# Altium schematic sheet Power_v2.SchDoc(Power_v2)
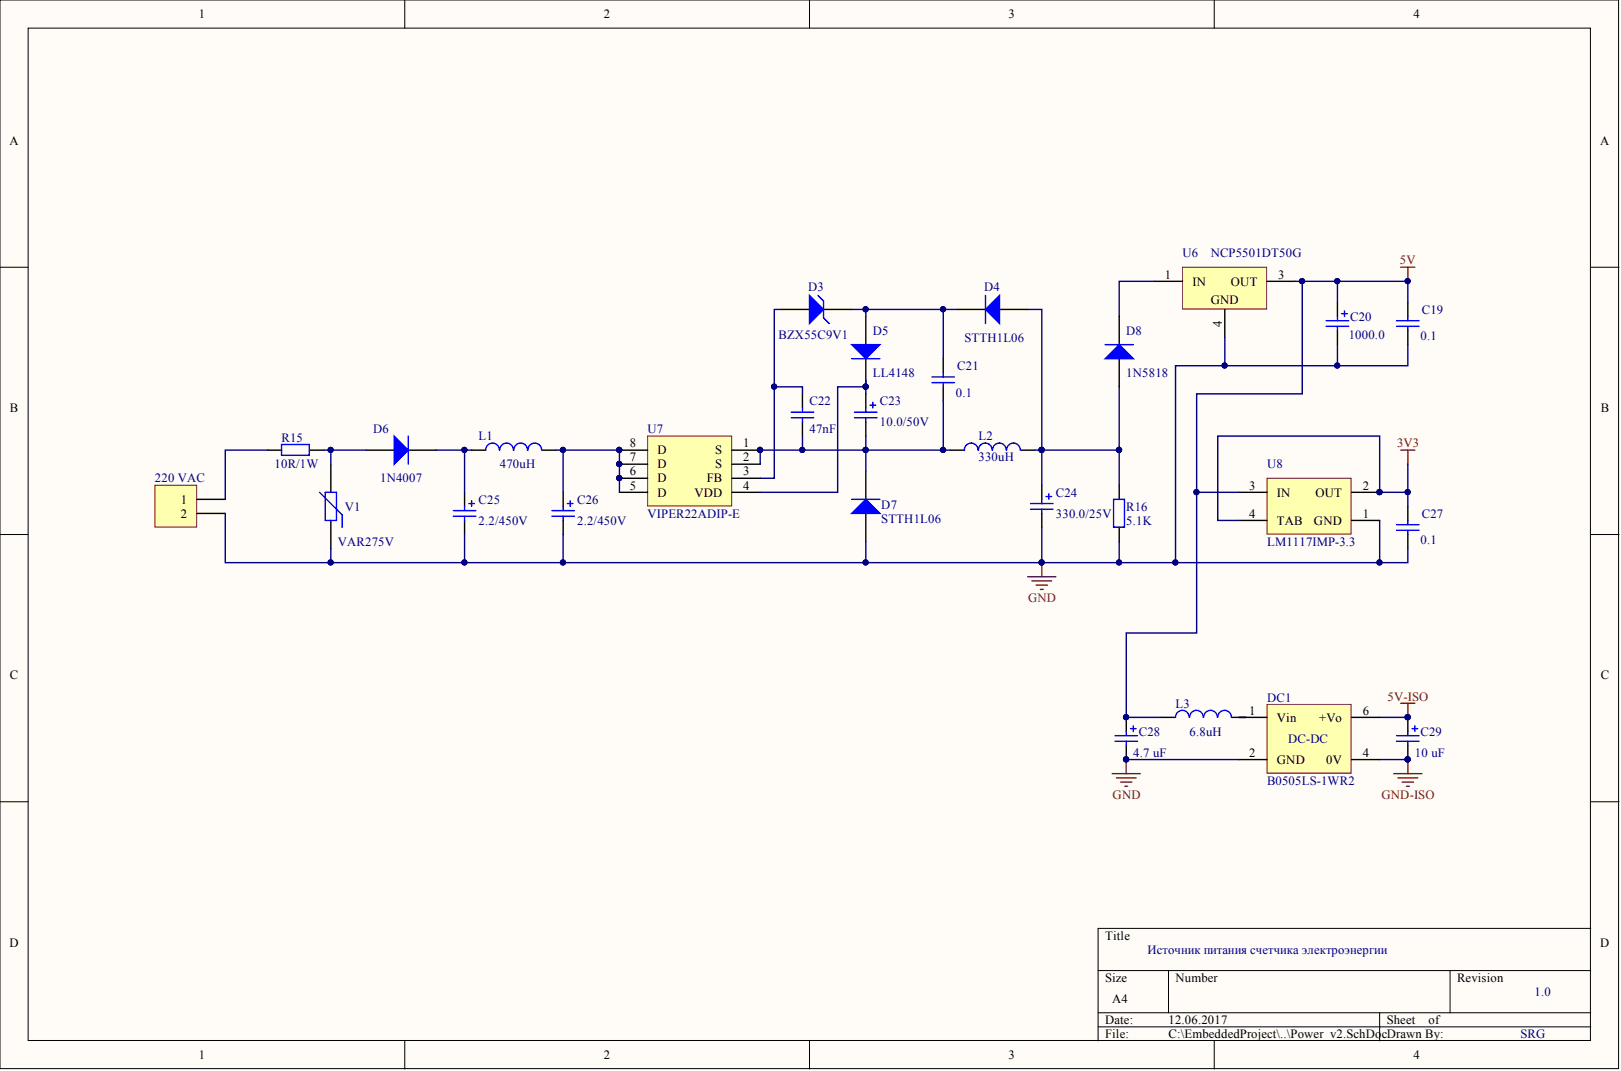
[diagram p0: Power_v2.SchDoc]

## Parts
220_VAC  Header 2  [TS-501 2P-2.5]  (value not drawn)
C19  value 0.1  [C1206]  (hidden comment: Cap)
C20  value 1000.0  [El-d10-h16-F5.08]  (hidden comment: Cap Pol2)
C21  value 0.1  [C1206]  (hidden comment: Cap)
C22  value 47nF  [C1206]  (hidden comment: Cap)
C23  value 10.0/50V  [El-d5-h11-F2.54]  (hidden comment: Cap Pol2)
C24  value 330.0/25V  [El-d8-h12-F3.81]  (hidden comment: Cap Pol2)
C25  value 2.2/450V  [El-d10-h13-F5.08]  (hidden comment: Cap Pol2)
C26  value 2.2/450V  [El-d10-h13-F5.08]  (hidden comment: Cap Pol2)
C27  value 0.1  [C1206]  (hidden comment: Cap)
C28  value 4.7 uF  [C]  (hidden comment: Polarized Capacitor)
C29  value 10 uF  [C]  (hidden comment: Polarized Capacitor)
D3  value BZX55C9V1  PartNumber: BZX55C9V1  [DO-35-300]  (hidden comment: D Zener)
D4  value STTH1L06  PartNumber: STTH1L06  [DO-214AA]  (hidden comment: Diode)
D5  value LL4148  PartNumber: LL4148  [D1206]  (hidden comment: Diode)
D6  value 1N4007  PartNumber: 1N4007  [DO-41-300]  (hidden comment: Diode)
D7  value STTH1L06  PartNumber: STTH1L06  [DO-214AA]  (hidden comment: Diode)
D8  value 1N5818  PartNumber: 1N5818  [DO-41-300]  (hidden comment: Diode)
DC1  B0505LS-1WR2  [SIP7]
L1  value 470uH  [Dr-CW8A]  (hidden comment: Inductor)
L2  value 330uH  [Dr-CW8A]  (hidden comment: Inductor)
L3  value 6.8uH  [Axial 12]  (hidden comment: Inductor)
R15  value 10R/1W  [R1W CF-500]  (hidden comment: Res2)
R16  value 5.1K  [R1206]  (hidden comment: Res2)
U6  NCP5501DT50G  [TO-252_L]
U7  value VIPER22ADIP-E  PartNumber: VIPER22ADIP-E  [DIL8-300a]  (hidden comment: VIPER12ADIP)
U8  LM1117IMP-3.3  [MP04A_N]
V1  value VAR275V  [Var-10K-300]  (hidden comment: Res Varistor)

## Nets
3V3: C27.2 U8.2(OUT) U8.4(TAB)
5V: C19.2 C20.1 C28.1 L3.1 U6.3 U8.3(IN)
5V-ISO: C29.1 DC1.6(+Vo)
GND: 220_VAC.2 C19.1 C20.2 C24.2 C25.2 C26.2 C27.1 C28.2 D7.1 DC1.2(GND) R16.1 U6.4 U8.1(GND) V1.1
GND-ISO: C29.2 DC1.4(0V)
Net220 VAC_1: 220_VAC.1 R15.1
NetC21_1: C21.1 C22.1 C23.2 D7.2 L2.1 U7.1(S) U7.2(S)
NetC21_2: C21.2 D3.2 D4.2 D5.1
NetC22_2: C22.2 D3.1 U7.3(FB)
NetC23_1: C23.1 D5.2 U7.4(VDD)
NetC24_1: C24.1 D4.1 D8.1 L2.2 R16.2
NetC25_1: C25.1 D6.2 L1.1
NetC26_1: C26.1 L1.2 U7.5(D) U7.6(D) U7.7(D) U7.8(D)
NetD6_1: D6.1 R15.2 V1.2
NetD8_2: D8.2 U6.1
NetDC1_1: DC1.1(Vin) L3.2

## Library data of the parts
220_VAC = Header 2: Header, 2-Pin; PartNumber: 301-021-12; DeliveryTime: 7; Value: 301-021-12; Component Kind: Standard; Library Name: Miscellaneous Connectors.IntLib; Price: 15.00; Signal Integrity: Connector
C19 C21 C27 = Cap: Capacitor; PartNumber: GRM31CR72A104K; DeliveryTime: 7; Component Kind: Standard; Library Name: Miscellaneous Devices.IntLib; Price: 10.00; Signal Integrity: Cap; Simulation: CAP
C20 = Cap Pol2: Polarized Capacitor (Axial); PartNumber: TK 10X16 TKR102M1CG16; DeliveryTime: 7; Component Kind: Standard; Library Name: Miscellaneous Devices.IntLib; Price: 14.00; Signal Integrity: Cap Pol; Simulation: CAP
C22 = Cap: Capacitor; PartNumber: GRM31MR72A473K; DeliveryTime: 7; Component Kind: Standard; Library Name: Miscellaneous Devices.IntLib; Price: 4.00; Signal Integrity: Cap; Simulation: CAP
C23 = Cap Pol2: Polarized Capacitor (Axial); PartNumber: TK 5X11 TKR100M1HD11; DeliveryTime: 7; Component Kind: Standard; Library Name: Miscellaneous Devices.IntLib; Price: 3.00; Signal Integrity: Cap Pol; Simulation: CAP
C24 = Cap Pol2: Polarized Capacitor (Axial); PartNumber: ECAP 330uF/ 25V; DeliveryTime: 7; Component Kind: Standard; Library Name: Miscellaneous Devices.IntLib; Price: 8.00; Signal Integrity: Cap Pol; Simulation: CAP
C25 C26 = Cap Pol2: Polarized Capacitor (Axial); PartNumber: TK 10X13, TKR2R2M2WG13; DeliveryTime: 7; Component Kind: Standard; Library Name: Miscellaneous Devices.IntLib; Price: 11.00; Signal Integrity: Cap Pol; Simulation: CAP
C28 = Cap Pol2: PartNumber: TECAP, 4.7 мкФ, 16В, тип C, 20%; DeliveryTime: 7; Component Kind: Standard; Description: Polarized Capacitor; Library Name: Miscellaneous Devices.IntLib; Price: 7.00; Signal Integrity: Cap Pol; Simulation: CAP
C29 = Cap Pol2: PartNumber: TECAP, 10 мкФ, 20В, тип C, 20%; DeliveryTime: 7; Component Kind: Standard; Description: Polarized Capacitor; Library Name: Miscellaneous Devices.IntLib; Price: 25.00; Signal Integrity: Cap Pol; Simulation: CAP
D3 = D Zener: Zener Diode; DeliveryTime: 7; Component Kind: Standard; Library Name: Miscellaneous Devices.IntLib; Price: 2.00; Signal Integrity: D Zener; Simulation: ZENER
D4 D7 = Diode: Default Diode; DeliveryTime: 21; Component Kind: Standard; Library Name: Miscellaneous Devices.IntLib; Price: 8.00; Signal Integrity: Diode; Simulation: DIODE
D5 D6 D8 = Diode: Default Diode; DeliveryTime: 7; Component Kind: Standard; Library Name: Miscellaneous Devices.IntLib; Signal Integrity: Diode; Simulation: DIODE
  D5: Price: 1.0
  D6 D8: Price: 3.00
DC1 = B0505LS-1WR2: DC/DC 5V/5V-200mA; DeliveryTime: 7; Component Kind: Standard; Library Name: Schlib1.SchLib; PartNumber: B0505LS-1WR2; Price: 270.00; Value: B0505LS-1WR2
L1 = Inductor: PartNumber: RLB0914-471KL; DeliveryTime: 7; Component Kind: Standard; Description: Inductor; Library Name: Miscellaneous Devices.IntLib; Price: 30.00; Signal Integrity: Inductor; Simulation: INDUCTOR
L2 = Inductor: PartNumber: RLB0914-331KL; DeliveryTime: 21; Component Kind: Standard; Description: Inductor; Library Name: Miscellaneous Devices.IntLib; Price: 30.00; Signal Integrity: Inductor; Simulation: INDUCTOR
L3 = Inductor: PartNumber: EC24-6R8K, 10%; DeliveryTime: 7; Component Kind: Standard; Description: Inductor; Library Name: Miscellaneous Devices.IntLib; Price: 8.00; Signal Integrity: Inductor; Simulation: INDUCTOR
R15 = Res2: Resistor; PartNumber: ROX1SJ10R; DeliveryTime: 21; Component Kind: Standard; Library Name: Miscellaneous Devices.IntLib; Price: 11.00; Signal Integrity: Res; Simulation: RESISTOR
R16 = Res2: Resistor; PartNumber: RC1206JK-075K1L; DeliveryTime: 7; Component Kind: Standard; Library Name: Miscellaneous Devices.IntLib; Price: 0.90; Signal Integrity: Res; Simulation: RESISTOR
U6 = LM78M05CDT: 3-Terminal Positive Voltage Regulators, 3-pin TO-252; DeliveryTime: 21; Component Kind: Standard; Library Name: National Semiconductor LDO.IntLib; PartNumber: NCP5501DT50G; Price: 52.00; Value: NCP5501DT50G
U7 = VIPER12ADIP: Low power offline switched-mode power; DeliveryTime: 5; Component Kind: Standard; Library Name: Schlib1.SchLib; Price: 28.00
U8 = LM1117IMP-3.3: 800mA Low-Dropout Linear Regulator, 4-pin SOT-223; PartNumber: LM1117IMP-3.3/NOPB; DeliveryTime: 7; Component Kind: Standard; Library Name: National Semiconductor LDO.IntLib; Price: 43.00; Value: LM1117IMP-3.3
V1 = Res Varistor: Varistor (Voltage-Sensitive Resistor); PartNumber: B72214-S 271-K101 (S14K275), 275 В, 71 Дж; DeliveryTime: 7; Component Kind: Standard; Library Name: Miscellaneous Devices.IntLib; Price: 25
